# Altium schematic sheet power.SchDoc(power)
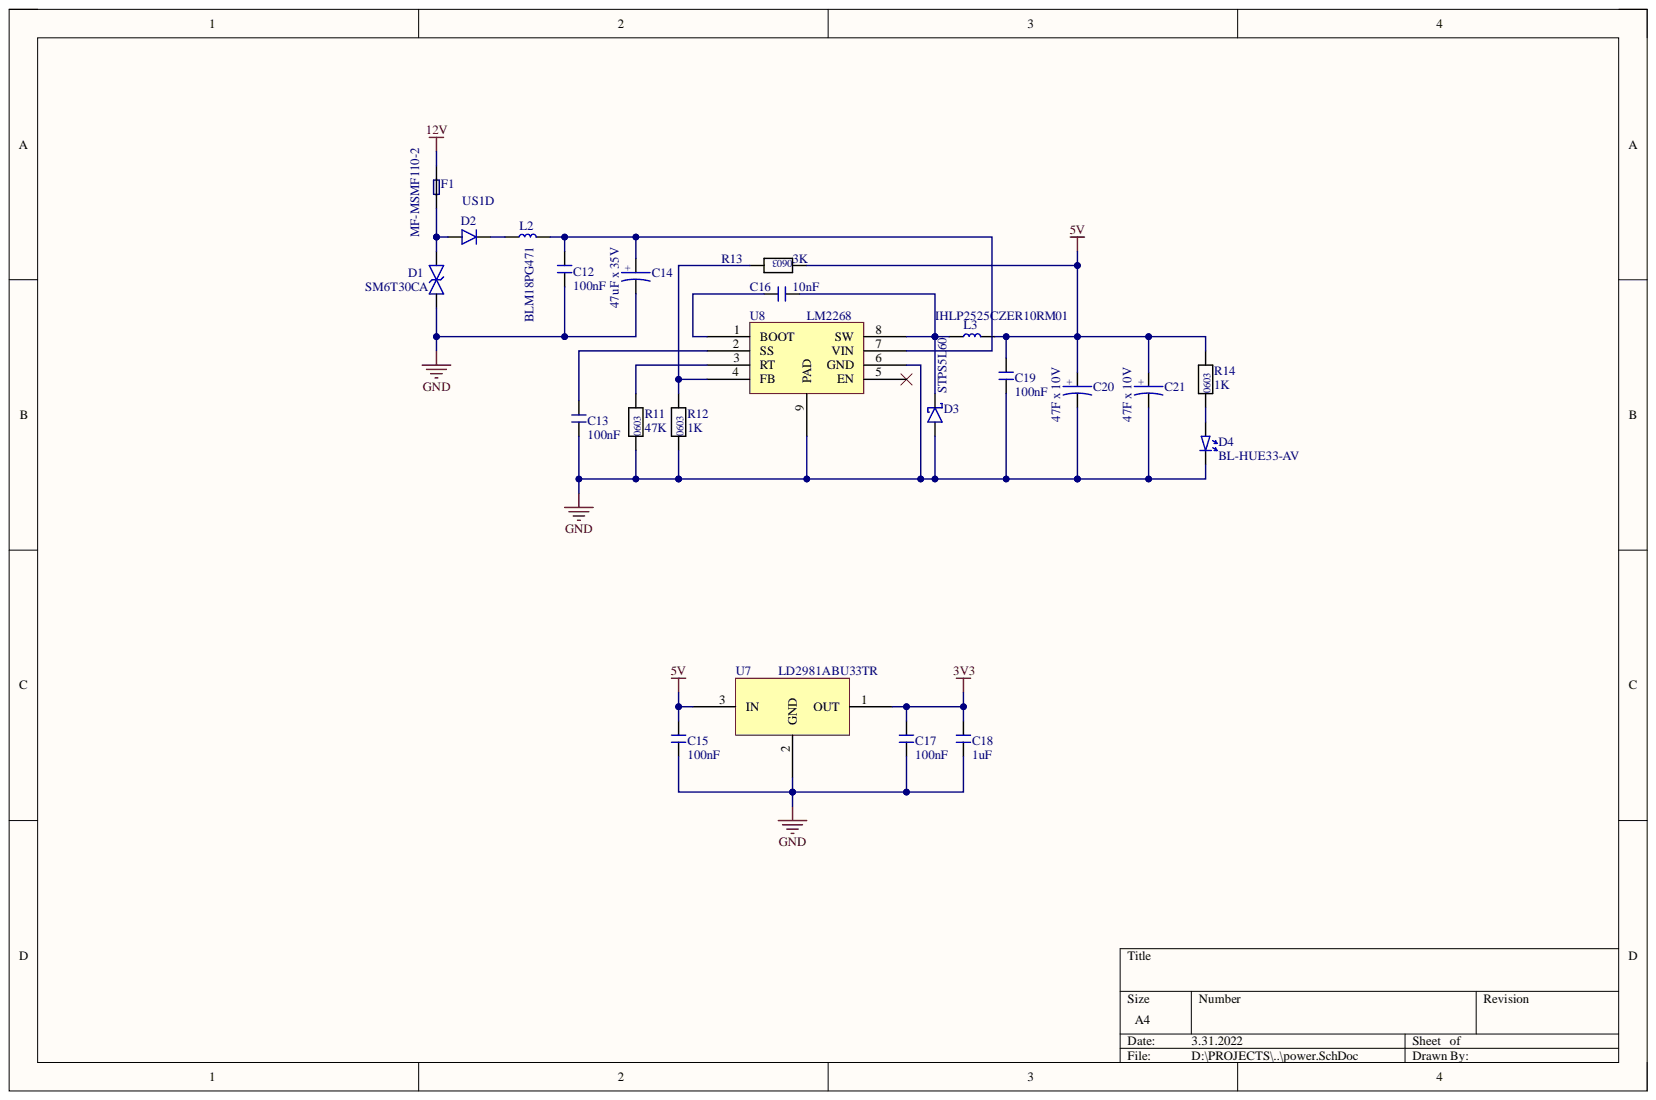
[diagram p0: power.SchDoc]

## Parts
C12  100nF  [CHIP_C_0603]
C13  100nF  [CHIP_C_0603]
C14  47uF x 35V  [TANTAL - E]
C15  100nF  [CHIP_C_0603]
C16  10nF  [CHIP_C_0603]
C17  100nF  [CHIP_C_0603]
C18  1uF  [CHIP_C_0603]
C19  100nF  [CHIP_C_0603]
C20  47F x 10V  [Tantal - B]
C21  47F x 10V  [Tantal - B]
D1  SM6T30CA  [DO-214AA]
D2  US1D  [DO-214AC]
D3  STPS5L60  [DO-214AB]
D4  BL-HUE33-AV  [CHIP_D_0603]
F1  MF-MSMF110-2  [MF-MSMFxxx]
L2  BLM18PG471  [CHIP_R_0603]
L3  IHLP2525CZER10RM01  [IHLP-2525CZ]
R11  47K  [CHIP_R_0603]
R12  1K  [CHIP_R_0603]
R13  3K  [CHIP_R_0603]
R14  1K  [CHIP_R_0603]
U7  LD2981ABU33TR  [SOT89]
U8  LM2268  [SOIC8P]

## Nets
3V3: C17.2 C18.2 U7.1
5V: C15.2 C19.1 C20.1 C21.1 L3.2 R13.1 R14.2 U7.3
12V: F1.1
GND: C12.2 C13.2 C14.2 C15.1 C17.1 C18.1 C19.2 C20.2 C21.2 D1.2 D3.1 D4.2 R11.1 R12.1 U7.2 U8.6(GND) U8.9(PAD)
NetC12_1: C12.1 C14.1 L2.2 U8.7(VIN)
NetC13_1: C13.1 U8.2(SS)
NetC16_1: C16.1 D3.2 L3.1 U8.8(SW)
NetC16_2: C16.2 U8.1(BOOT)
NetD1_1: D1.1 D2.1 F1.2
NetD2_2: D2.2 L2.1
NetD4_1: D4.1 R14.1
NetR11_2: R11.2 U8.3(RT)
NetR12_2: R12.2 R13.2 U8.4(FB)

Not connected: U8 5(EN)

## Library data of the parts
C12 C13 C15-C19 = CHIP_C: capacitor ceramic; Component Kind: Standard; Library Name: Popular.SchLib
C14 C20 C21 = Tantal: Component Kind: Standard; Library Name: Popular.SchLib
D1 = SM6T27CA: ESD protection; Component Kind: Standard; Library Name: Popular.SchLib
D2 = US-1D: Surface Mount Ultra Fast Rectifier; Component Kind: Standard; Library Name: Popular.SchLib
D3 = 10MQ040: Rectifier diode; Component Kind: Standard; Library Name: Popular.SchLib
D4 = KP-3216: LED; Component Kind: Standard; Library Name: Popular.SchLib
F1 = miniSMDC014F-2: Component Kind: Standard; Library Name: Popular.SchLib
L2 = BLM: Ferrite Beads; Component Kind: Standard; Library Name: Popular.SchLib
L3 = CDRH74: Ferrite drum core construction; Component Kind: Standard; Library Name: Popular.SchLib
R11-R13 = RES_0603: Resistor; Component Kind: Standard; Library Name: Popular.SchLib
R14 = RES_0603: Component Kind: Standard; Library Name: Popular.SchLib
U7 = LD2981ABU33TR: Voltage Regulator; Component Kind: Standard; Library Name: Popular.SchLib
U8 = LM2268: Component Kind: Standard; Library Name: Popular.SchLib
